# Altium schematic sheet power.SchDoc
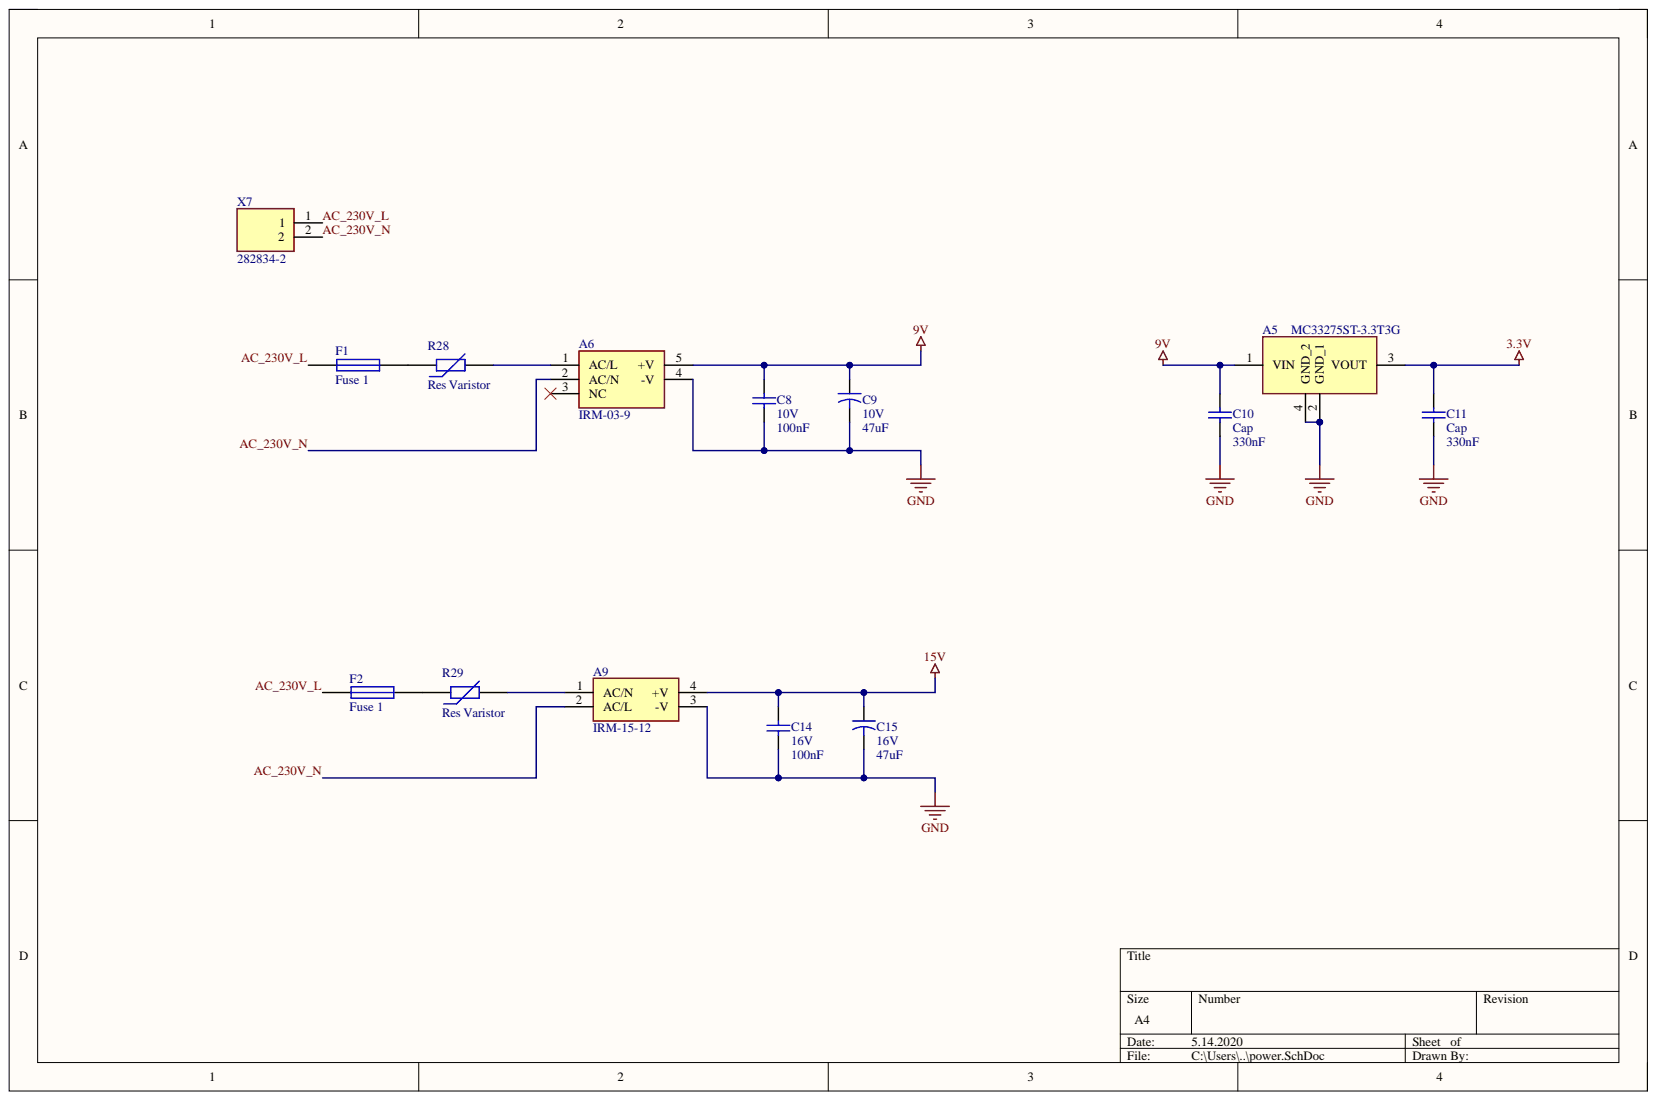
[diagram p0: power.SchDoc]

## Parts
A5  MC33275ST-3.3T3G  [SOT230P700X175-4N]
A6  IRM-03-9  [IRM039]
A9  IRM-15-12  [IRM-15-12]
C8  10V  value 100nF  [6-0805_L]
C9  10V  value 47uF  [CAPAE830X1050N]
C10  Cap  value 330nF  [6-0805_L]
C11  Cap  value 330nF  [6-0805_L]
C14  16V  value 100nF  [6-0805_L]
C15  16V  value 47uF  [CAPAE830X1050N]
F1  Fuse 1  [0751.0056]
F2  Fuse 1  [0751.0056]
R28  Res Varistor  [CAPR5-4X5]
R29  Res Varistor  [CAPR5-4X5]
X7  282834-2  [282834-2_1]

## Nets
3.3V: A5.3(VOUT) C11.2
9V: A5.1(VIN) A6.5(+V) C8.2 C9.1 C10.2
15V: A9.4(+V) C14.2 C15.1
GND: A5.2(GND_1) A5.4(GND_2) A6.4(-V) A9.3(-V) C8.1 C9.2 C10.1 C11.1 C14.1 C15.2
AC_230V_7_N: A6.2(AC/N) A9.2(AC/L) X7.2  [also labelled AC_230V_N]
AC_230V_L_7: F1.1 F2.1 X7.1  [also labelled AC_230V_L]
NetA6_1: A6.1(AC/L) R28.2
NetA9_1: A9.1(AC/N) R29.2
NetF1_2: F1.2 R28.1
NetF2_2: F2.2 R29.1

Not connected: A6 3(NC)

## Library data of the parts
A5 = MC33275ST-3.3T3G: Integrated Circuit; Manufacturer_Name: ON Semiconductor; Allied_Number: 70340056; Allied Price/Stock: https://www.alliedelec.com/on-semiconductor-mc33275st-3-3t3g/70340056/; Component Kind: Standard; Datasheet Link: http://www.onsemi.com/pub/Collateral/MC33275-D.PDF; Height: 1.75mm; Library Name: ALL.SchLib; Manufacturer_Part_Number: MC33275ST-3.3T3G; Mouser Part Number: 863-MC33275ST-3.3T3G; Mouser Price/Stock: https://www.mouser.com/Search/Refine.aspx?Keyword=863-MC33275ST-3.3T3G; RS Part Number: 7856910P; RS Price/Stock: http://uk.rs-online.com/web/p/products/7856910P
A6 = IRM-03-9: Power Supply; Manufacturer_Name: Mean Well; Allied_Number: 70879802; Allied Price/Stock: https://www.alliedelec.com/meanwellusa-irm-03-9/70879802/; Component Kind: Standard; Datasheet Link: https://componentsearchengine.com/Datasheets/2/IRM-03-9.pdf; Height: 15mm; Library Name: SamacSys2.SchLib; Manufacturer_Part_Number: IRM-03-9; Mouser Part Number: 709-IRM03-9; Mouser Price/Stock: https://www.mouser.com/Search/Refine.aspx?Keyword=709-IRM03-9; RS Part Number: 1359235P; RS Price/Stock: http://uk.rs-online.com/web/p/products/1359235P
A9 = IRM-15-12: Power Supply; Manufacturer_Name: Mean Well; Component Kind: Standard; Datasheet Link: https://www.meanwell-web.com/content/files/pdfs/productPdfs/MW/IRM-15-spec.pdf; Library Name: SamacSys2.SchLib; Manufacturer_Part_Number: IRM-15-12; Mouser2 Part Number: 709-IRM15-12; Mouser2 Price/Stock: https://www.mouser.com/Search/Refine.aspx?Keyword=709-IRM15-12
C8 C10 C11 C14 = Cap: Capacitor; Component Kind: Standard; LatestRevisionDate: 17-Jul-2002; LatestRevisionNote: Re-released for DXP Platform.; Library Name: Miscellaneous Devices.IntLib; PackageDescription: Radial Cap, Thru-Hole; 2 Leads; 0.3 in Pin Spacing; PackageReference: RAD-0.3; Published: 8-Jun-2000; Signal Integrity: Cap; Simulation: CAP; Publisher: Altium Limited
C9 C15 = Cap2: Capacitor; Component Kind: Standard; Library Name: Miscellaneous Devices.IntLib; PackageDescription: Capacitor; 2 Leads; PackageReference: CAPR5-4X5; Published: 23-Sep-2002; Signal Integrity: Cap; Simulation: CAP; Publisher: Altium Limited
F1 F2 = Fuse 1: Fuse; Component Kind: Standard; LatestRevisionDate: 17-Jul-2002; LatestRevisionNote: Re-released for DXP Platform.; Library Name: Miscellaneous Devices.IntLib; PackageDescription: Thru-Hole; 2 Leads; PackageReference: PIN-W2/E2.8; Published: 8-Jun-2000; Simulation: FUSE; Publisher: Altium Limited
R28 R29 = Res Varistor: Varistor (Voltage-Sensitive Resistor); Code_IPC: RESC2012; Component Kind: Standard; LatestRevisionDate: 29-May-2009; LatestRevisionNote: IPC-7351 Footprint Added.; Library Name: Miscellaneous Devices.IntLib; PackageDescription: Chip Resistor 0805 Case Size; PackageReference: 6-0805; PackageVersion: Mar-2003; Published: 24-Mar-1999; Publisher: Altium Limited
X7 = 282834-2: Connector  https://www.mouser.com/ProductDetail/TE-Connectivity/282834-2?qs=sGAEpiMZZMvZTcaMAxB2AJ%2F79sE4hFAYo1Yb6cyIxXA%3D; Manufacturer_Name: TE Connectivity; Component Kind: Standard; Datasheet Link: https://componentsearchengine.com/Datasheets/1/282834-2.pdf; Manufacturer_Part_Number: 282834-2; Mouser2 Part Number: 571-282834-2; Mouser2 Price/Stock: https://www.mouser.com/Search/Refine.aspx?Keyword=571-282834-2
